# Altium schematic sheet Sheet3.SchDoc(Sheet3)
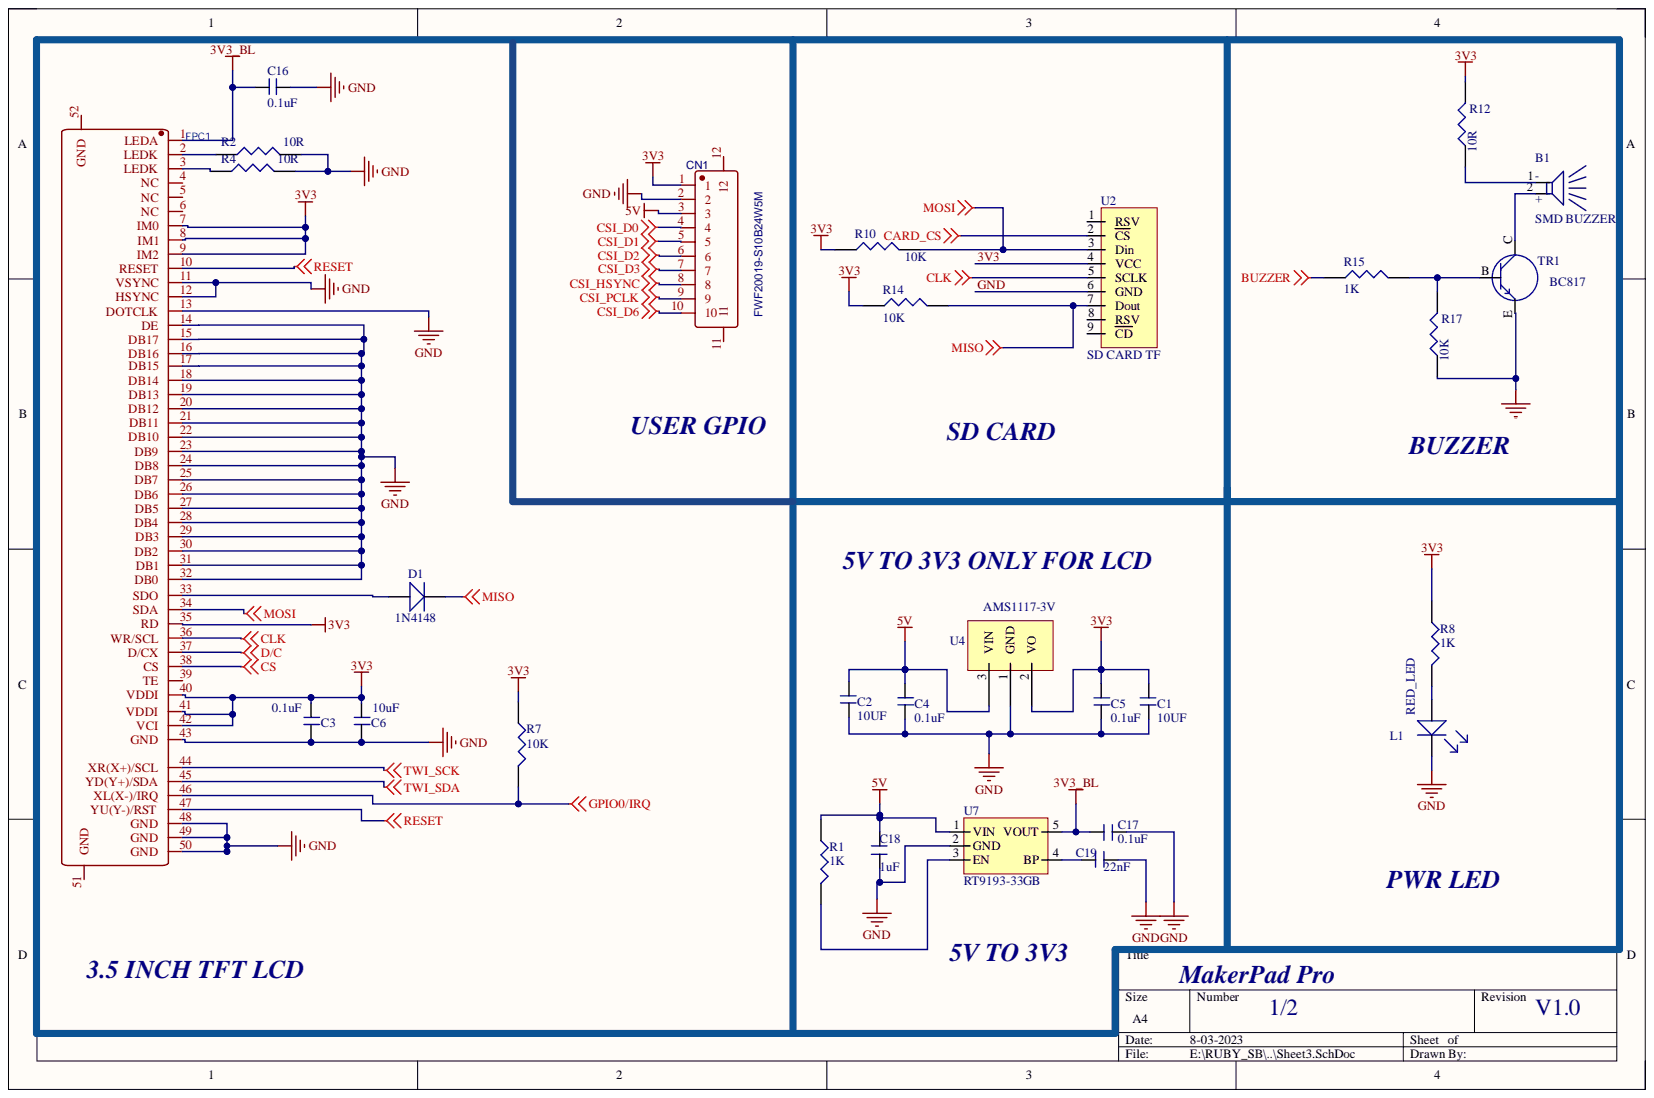
[diagram p0: Sheet3.SchDoc]

## Parts
B1  SMD BUZZER  [SMD BUZZ]
C1  10UF  [Tantlum_A_3216]
C2  10UF  [Tantlum_A_3216]
C3  0.1uF  [C_0603]
C4  0.1uF  [C_0603]
C5  0.1uF  [C_0603]
C6  10uF  [C_0603]
C16  0.1uF  [C_0603]
C17  0.1uF  [C_0603]
C18  1uF  [C_0603]
C19  22nF  [C_0603]
CN1  FWF20019-S10B24W5M  [1X10P 2MM MALE]
D1  1N4148  [LL4148_SOD80]
FPC1  3.5 INCH TFT LCD  [3.5 inch TF]
L1  RED_LED  [LED_0603]
R1  1K  [R_0603]
R2  10R  [R_0603]
R4  10R  [R_0603]
R7  10K  [R_0603]
R8  1K  [R_0603]
R10  10K  [R_0603]
R12  10R  [R_0603]
R14  10K  [R_0603]
R15  1K  [R_0603]
R17  10K  [R_0603]
TR1  BC817  [SOT23]
U2  SD CARD TF  [micro sd card]
U4  AMS1117-3V  [AMS1117_3V3]
U7  RT9193-33GB  [SOT23-5]

## Nets
3V3_BL: C16.2 C17.1 FPC1.1(LEDA) U7.5(VOUT)
5V: C2.1 C4.2 C18.1 CN1.3 R1.1 U4.3 U7.1(VIN)
BUZZER: R15.1
CARD_CS: U2.2(CS)
CLK: FPC1.36(WR/SCL) U2.5(SCLK)
CS: FPC1.38(CS)
CSI_D0: CN1.4
CSI_D1: CN1.5
CSI_D2: CN1.6
CSI_D3: CN1.7
CSI_D6: CN1.10
CSI_HSYNC: CN1.8
CSI_PCLK: CN1.9
D/C: FPC1.37(D/CX)
GPIO0/IRQ: FPC1.46(XL(X-)/IRQ) R7.2
MISO: D1.K R14.2 U2.7(Dout)
MOSI: FPC1.34(SDA) R10.2 U2.3(Din)
RESET: FPC1.10(RESET) FPC1.47(YU(Y-)/RST)
TWI_SCK: FPC1.44(XR(X+)/SCL)
TWI_SDA: FPC1.45(YD(Y+)/SDA)
3V3: C1.1 C3.2 C5.2 C6.2 CN1.1 FPC1.7(IM0) FPC1.8(IM1) FPC1.9(IM2) FPC1.35(RD) FPC1.40(VDDI) FPC1.41(VDDI) FPC1.42(VCI) R7.1 R8.1 R10.1 R12.2 R14.1 U2.4(VCC) U4.2
GND: C1.2 C2.2 C3.1 C4.1 C5.1 C6.1 C16.1 C17.2 C18.2 C19.1 CN1.2 FPC1.11(VSYNC) FPC1.12(HSYNC) FPC1.13(DOTCLK) FPC1.14(DE) FPC1.15(DB17) FPC1.16(DB16) FPC1.17(DB15) FPC1.18(DB14) FPC1.19(DB13) FPC1.20(DB12) FPC1.21(DB11) FPC1.22(DB10) FPC1.23(DB9) FPC1.24(DB8) FPC1.25(DB7) FPC1.26(DB6) FPC1.27(DB5) FPC1.28(DB4) FPC1.29(DB3) FPC1.30(DB2) FPC1.31(DB1) FPC1.32(DB0) FPC1.43(GND) FPC1.48(GND) FPC1.49(GND) FPC1.50(GND) L1.K R2.1 R4.1 R17.1 TR1.E U2.6(GND) U4.1 U7.2(GND)
NetB1_1: B1.1 R12.1
NetB1_2: B1.2 TR1.C
NetC19_2: C19.2 U7.4(BP)
NetD1_A: D1.A FPC1.33(SDO)
NetFPC1_2: FPC1.2(LEDK) R2.2
NetFPC1_3: FPC1.3(LEDK) R4.2
NetL1_A: L1.A R8.2
NetR1_2: R1.2 U7.3(EN)
NetR15_2: R15.2 R17.2 TR1.B

Not connected: CN1 11 12; FPC1 4(NC) 5(NC) 6(NC) 39(TE) 51(GND) 52(GND); U2 1(RSV) 8(RSV) 9(CD)

## Library data of the parts
B1 = BUZZER PIEZO: Component Kind: Standard; Library Name: dxp_pasl_lib.IntLib
C1-C6 C16-C19 = CAP_NONPOLAR: Component Kind: Standard; Library Name: dxp_pasl_lib.IntLib
CN1 = FWF20019-S10B24W5M: Manufacturer: TXGA(特思嘉); Contributor: LCSC; Component Kind: Standard; JLCPCB Part Class: Extended Part; Manufacturer Part: FWF20019-S10B24W5M; spicePre: C; spiceSymbolName: FWF20019-S10B24W5M; Supplier: LCSC; Supplier Part: C2834265
D1 = DIODE: SOD-123 Schottky Barrier Diodes (SBD) ROHS #C77336; Component Kind: Standard; Library Name: dxp_pasl_lib.IntLib
FPC1 = 3.5 INCH-50P: Component Kind: Standard; Library Name: MIX.SchLib
L1 = LED: Component Kind: Standard; Library Name: dxp_pasl_lib.IntLib
R1 R2 R4 R7 R8 R10 R12 R14 R15 R17 = RES: Component Kind: Standard; Library Name: dxp_pasl_lib.IntLib
TR1 = TRANSISTER_NPN: Component Kind: Standard; Library Name: dxp_pasl_lib.IntLib
U2 = SD CARD TF: Micro SD Memory Card Socket; Component Kind: Standard; Library Name: dxp_pasl_lib.IntLib
U4 = AMS1117: Component Kind: Standard; Library Name: dxp_pasl_lib.IntLib
U7 = RT9193-33GB: 300mA 70dB@(100Hz),50dB@(10kHz) 300mV@(300mA) Fixed 3.3V~3.3V Positive 1 5.5V SOT-23-5 Linear Voltage Regulators (LDO) ROHS #C15651; Component Kind: Standard; Library Name: dxp_pasl_lib.IntLib
